# Altium schematic sheet AmplifierRFMain_MAIN.SchDoc(AmplifierRFMain_MAIN)
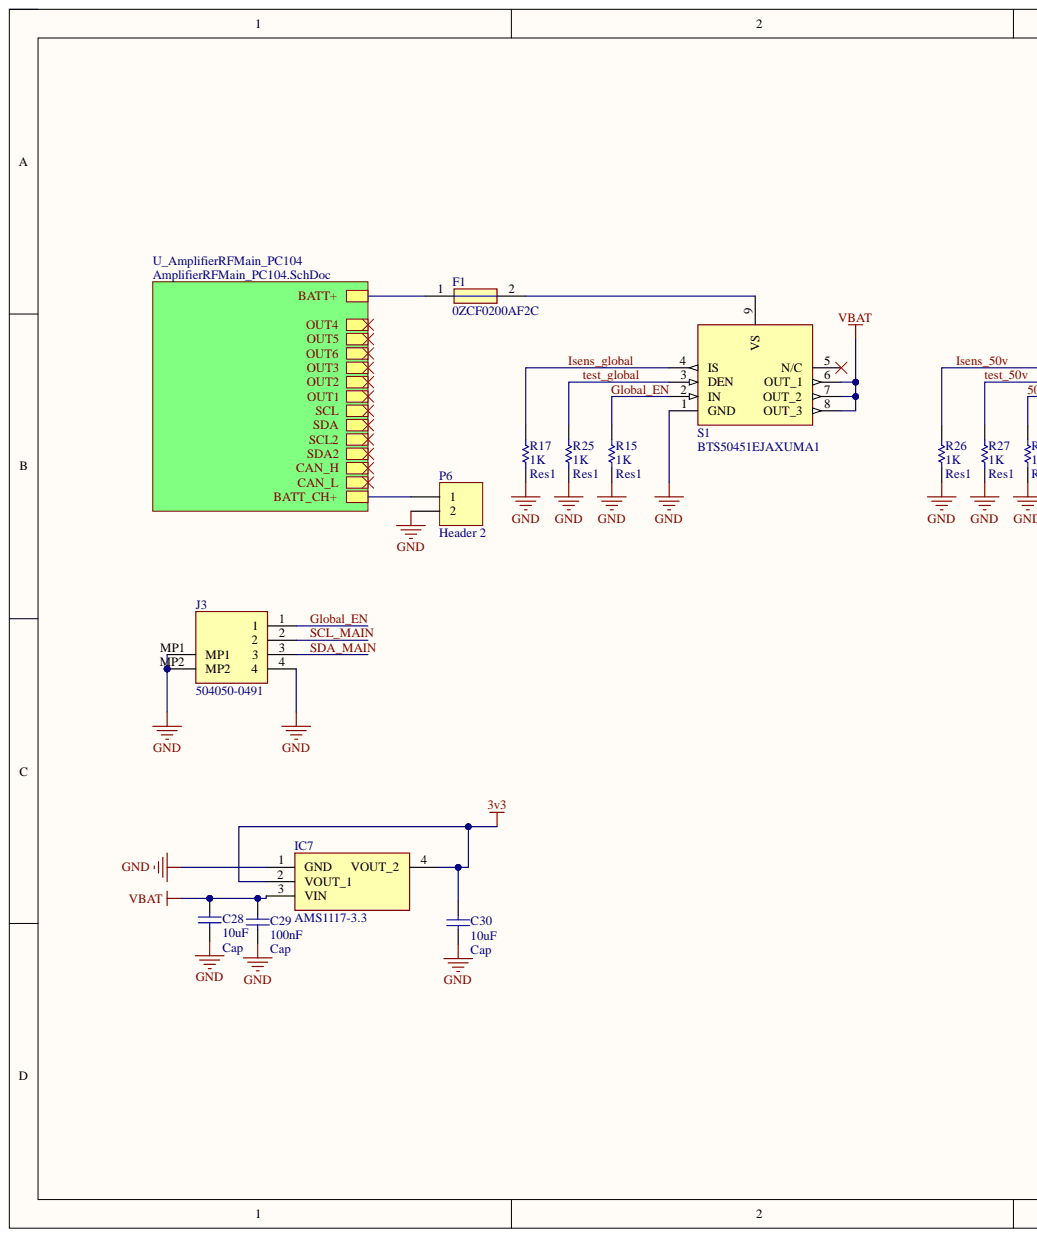
[diagram p0: AmplifierRFMain_MAIN.SchDoc, left]
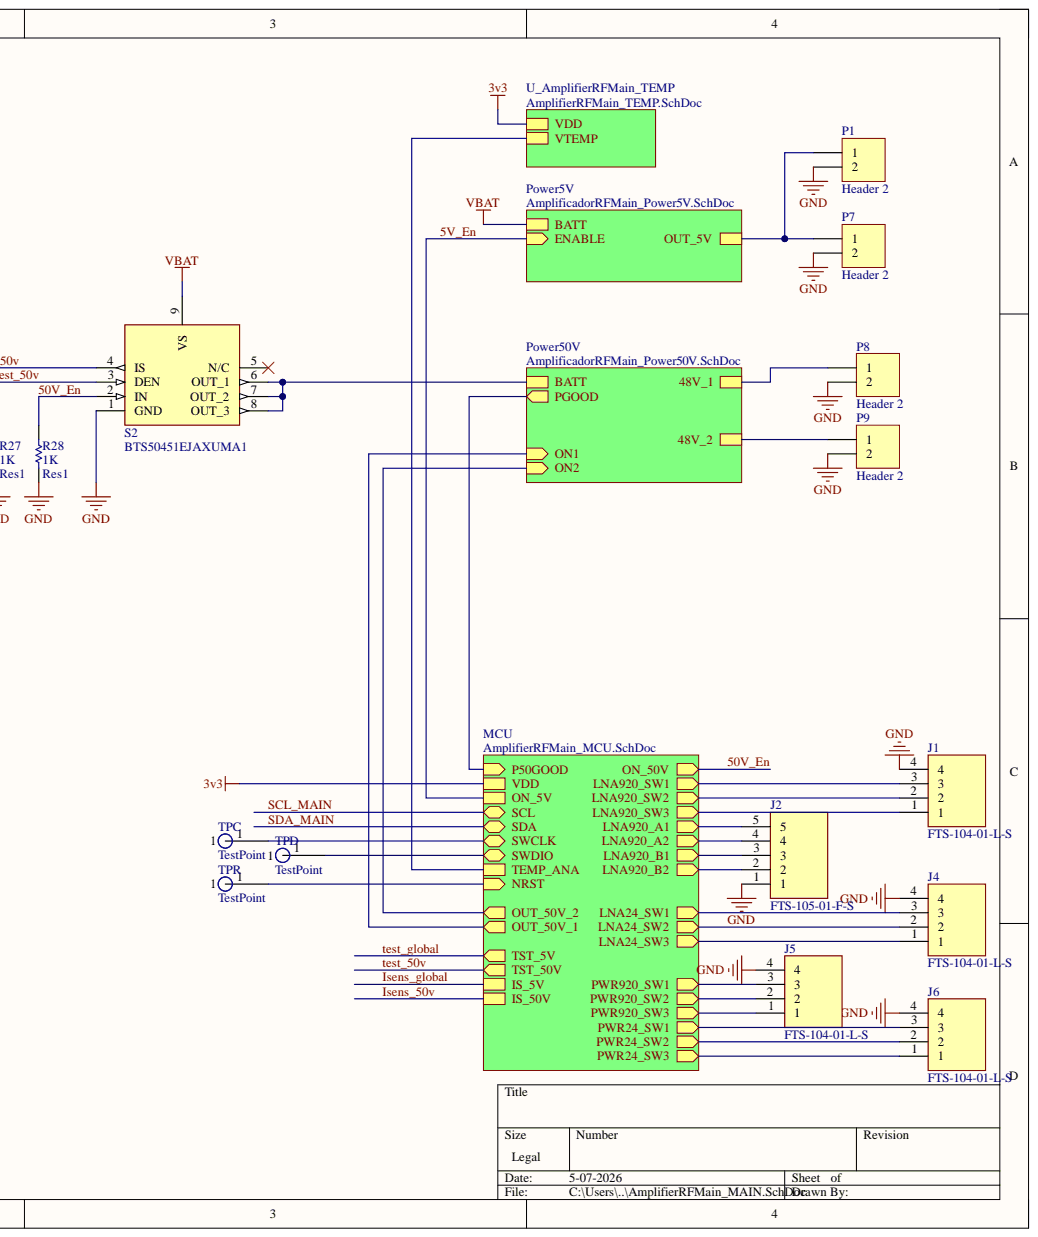
[diagram p1: AmplifierRFMain_MAIN.SchDoc, right]

## Parts
C28  Cap  value 10uF  [1608[0603]]
C29  Cap  value 100nF  [0402]
C30  Cap  value 10uF  [1608[0603]]
F1  0ZCF0200AF2C  [FUSC7451X80N]
IC7  AMS1117-3.3  [SOT229P700X180-4N]
J1  FTS-104-01-L-S  [FTS10401LS]
J2  FTS-105-01-F-S  [FTS10501FS]
J3  504050-0491  [5040500491]
J4  FTS-104-01-L-S  [FTS10401LS]
J5  FTS-104-01-L-S  [FTS10401LS]
J6  FTS-104-01-L-S  [FTS10401LS]
P1  Header 2  [HDR1X2]
P6  Header 2  [HDR1X2]
P7  Header 2  [HDR1X2]
P8  Header 2  [HDR1X2]
P9  Header 2  [HDR1X2]
R15  Res1  value 1K  [0402]
R17  Res1  value 1K  [0402]
R25  Res1  value 1K  [0402]
R26  Res1  value 1K  [0402]
R27  Res1  value 1K  [0402]
R28  Res1  value 1K  [0402]
S1  BTS50451EJAXUMA1  [SOIC127P600X170-9N]
S2  BTS50451EJAXUMA1  [SOIC127P600X170-9N]
TPC  TestPoint  [Testpoint]
TPD  TestPoint  [Testpoint]
TPR  TestPoint  [Testpoint]

## Nets
3v3: C30.2 IC7.2(VOUT_1) IC7.4(VOUT_2)
GND: C28.1 C29.1 C30.1 IC7.1(GND) J1.4 J2.1 J3.4 J3.MP1 J3.MP2 J4.4 J5.4 J6.4 P1.2 P6.2 P7.2 P8.2 P9.2 R15.1 R17.1 R25.1 R26.1 R27.1 R28.1 S1.1(GND) S2.1(GND)
VBAT: C28.2 C29.2 IC7.3(VIN) S1.6(OUT_1) S1.7(OUT_2) S1.8(OUT_3) S2.9(VS)
50V_En: R28.2 S2.2(IN)
Global_EN: J3.1 R15.2 S1.2(IN)
Isens_50v: R26.2 S2.4(IS)
Isens_global: R17.2 S1.4(IS)
SCL_MAIN: J3.2
SDA_MAIN: J3.3
test_50v: R27.2 S2.3(DEN)
test_global: R25.2 S1.3(DEN)
NetF1_1: F1.1
NetF1_2: F1.2 S1.9(VS)
NetJ1_1: J1.1
NetJ1_2: J1.2
NetJ1_3: J1.3
NetJ2_2: J2.2
NetJ2_3: J2.3
NetJ2_4: J2.4
NetJ2_5: J2.5
NetJ4_1: J4.1
NetJ4_2: J4.2
NetJ4_3: J4.3
NetJ5_1: J5.1
NetJ5_2: J5.2
NetJ5_3: J5.3
NetJ6_1: J6.1
NetJ6_2: J6.2
NetJ6_3: J6.3
NetP1_1: P1.1 P7.1
NetP6_1: P6.1
NetP8_1: P8.1
NetP9_1: P9.1
NetS1_5: S1.5(N/C)
NetS2_5: S2.5(N/C)
NetS2_6: S2.6(OUT_1) S2.7(OUT_2) S2.8(OUT_3)
NetTPC_1: TPC.1
NetTPD_1: TPD.1
NetTPR_1: TPR.1
5V_En:
CAN_H:
CAN_L:
ON1:
ON2:
OUT1:
OUT2:
OUT3:
OUT4:
OUT5:
OUT6:
P50GOOD:
SCL:
SCL2:
SDA:
SDA2:
TEMP_ANA:

## Library data of the parts
C28-C30 = Cap: Capacitor; Component Kind: Standard; LatestRevisionDate: 17-Jul-2002; LatestRevisionNote: Re-released for DXP Platform.; Library Name: Miscellaneous Devices.IntLib; PackageDescription: Radial Cap, Thru-Hole; 2 Leads; 0.3 in Pin Spacing; PackageReference: RAD-0.3; Published: 8-Jun-2000; Signal Integrity: Cap; Simulation: CAP; Publisher: Altium Limited
F1 = 0ZCF0200AF2C: Fuse; Manufacturer_Name: BelFuse; Arrow Part Number: 0ZCF0200AF2C; Arrow Price/Stock: https://www.arrow.com/en/products/0zcf0200af2c/bel-group; Component Kind: Standard; Datasheet Link: https://belfuse.com/resources/datasheets/circuitprotection/ds-cp-0zcf-series.pdf; Height: 0.8mm; Manufacturer_Part_Number: 0ZCF0200AF2C; Mouser Part Number: 530-0ZCF0200AF2C; Mouser Price/Stock: https://www.mouser.co.uk/ProductDetail/Bel-Fuse/0ZCF0200AF2C?qs=SRYZG9HaIQ0z1l91Y1FaWg%3D%3D
IC7 = AMS1117-3.3: Integrated Circuit; Manufacturer_Name: ams OSRAM; Component Kind: Standard; Datasheet Link: http://www.advanced-monolithic.com/pdf/ds1117.pdf; Height: 1.8mm; Library Name: SamacSys.SchLib; Manufacturer_Part_Number: AMS1117-3.3
J1 J4-J6 = FTS-104-01-L-S: Connector; Manufacturer_Name: SAMTEC; Arrow Part Number: FTS-104-01-L-S; Arrow Price/Stock: https://www.arrow.com/en/products/fts-104-01-l-s/samtec; Component Kind: Standard; Datasheet Link: https://www.mouser.com/datasheet/2/527/fts-1369972.pdf; Height: 3.91mm; Manufacturer_Part_Number: FTS-104-01-L-S; Mouser Part Number: 200-FTS10401LS; Mouser Price/Stock: https://www.mouser.co.uk/ProductDetail/Samtec/FTS-104-01-L-S?qs=0lQeLiL1qyYia1s%2FLoscrA%3D%3D
J2 = FTS-105-01-F-S: Connector; Manufacturer_Name: SAMTEC; Arrow Part Number: FTS-105-01-F-S; Arrow Price/Stock: https://www.arrow.com/en/products/fts-105-01-f-s/samtec; Component Kind: Standard; Datasheet Link: https://www.samtec.com/products/fts; Manufacturer_Part_Number: FTS-105-01-F-S; Mouser Part Number: 200-FTS10501FS; Mouser Price/Stock: https://www.mouser.co.uk/ProductDetail/Samtec/FTS-105-01-F-S?qs=Cqqh%252BS766wmVOnO1VA4YWg%3D%3D
J3 = 504050-0491: Connector; Manufacturer_Name: Molex; Component Kind: Standard; Datasheet Link: http://www.molex.com/pdm_docs/sd/5040500491_sd.pdf; Height: 2.15mm; Library Name: SamacSys.SchLib; Manufacturer_Part_Number: 504050-0491; Mouser Part Number: 538-504050-0491; Mouser Price/Stock: https://www.mouser.co.uk/ProductDetail/Molex/504050-0491?qs=yXHQdf%252Bjwlut3yyE1%252ByhMA%3D%3D
P1 P6-P9 = Header 2: Header, 2-Pin; Component Kind: Standard; LatestRevisionDate: 17-Jul-2002; LatestRevisionNote: Re-released for DXP Platform.; Library Name: Miscellaneous Connectors.IntLib; Signal Integrity: Connector; Publisher: Altium Limited
R15 R17 R25-R28 = Res1: Resistor; Component Kind: Standard; LatestRevisionDate: 17-Jul-2002; LatestRevisionNote: Re-released for DXP Platform.; Library Name: Miscellaneous Devices.IntLib; PackageDescription: Axial Device, Thru-Hole; 2 Leads; 0.3 in Pin Spacing; PackageReference: AXIAL-0.3; Published: 8-Jun-2000; Signal Integrity: Res; Simulation: RESISTOR; Publisher: Altium Limited
S1 S2 = BTS50451EJAXUMA1: Switch; Manufacturer_Name: Infineon; Arrow Part Number: BTS50451EJAXUMA1; Arrow Price/Stock: https://www.arrow.com/en/products/bts50451ejaxuma1/infineon-technologies-ag?utm_currency=USD&region=nac; Component Kind: Standard; Datasheet Link: http://uk.rs-online.com/web/p/products/1109103; Height: 1.7mm; Manufacturer_Part_Number: BTS50451EJAXUMA1; Mouser Part Number: 726-BTS50451EJAXUMA1; Mouser Price/Stock: https://www.mouser.co.uk/ProductDetail/Infineon-Technologies/BTS50451EJAXUMA1?qs=K00xGehIljsEg52KhYWU0A%3D%3D
TPC TPD TPR = TestPoint: Component Kind: Standard; Library Name: SamacSys.SchLib
